# Altium schematic sheet LED.SchDoc(LED)
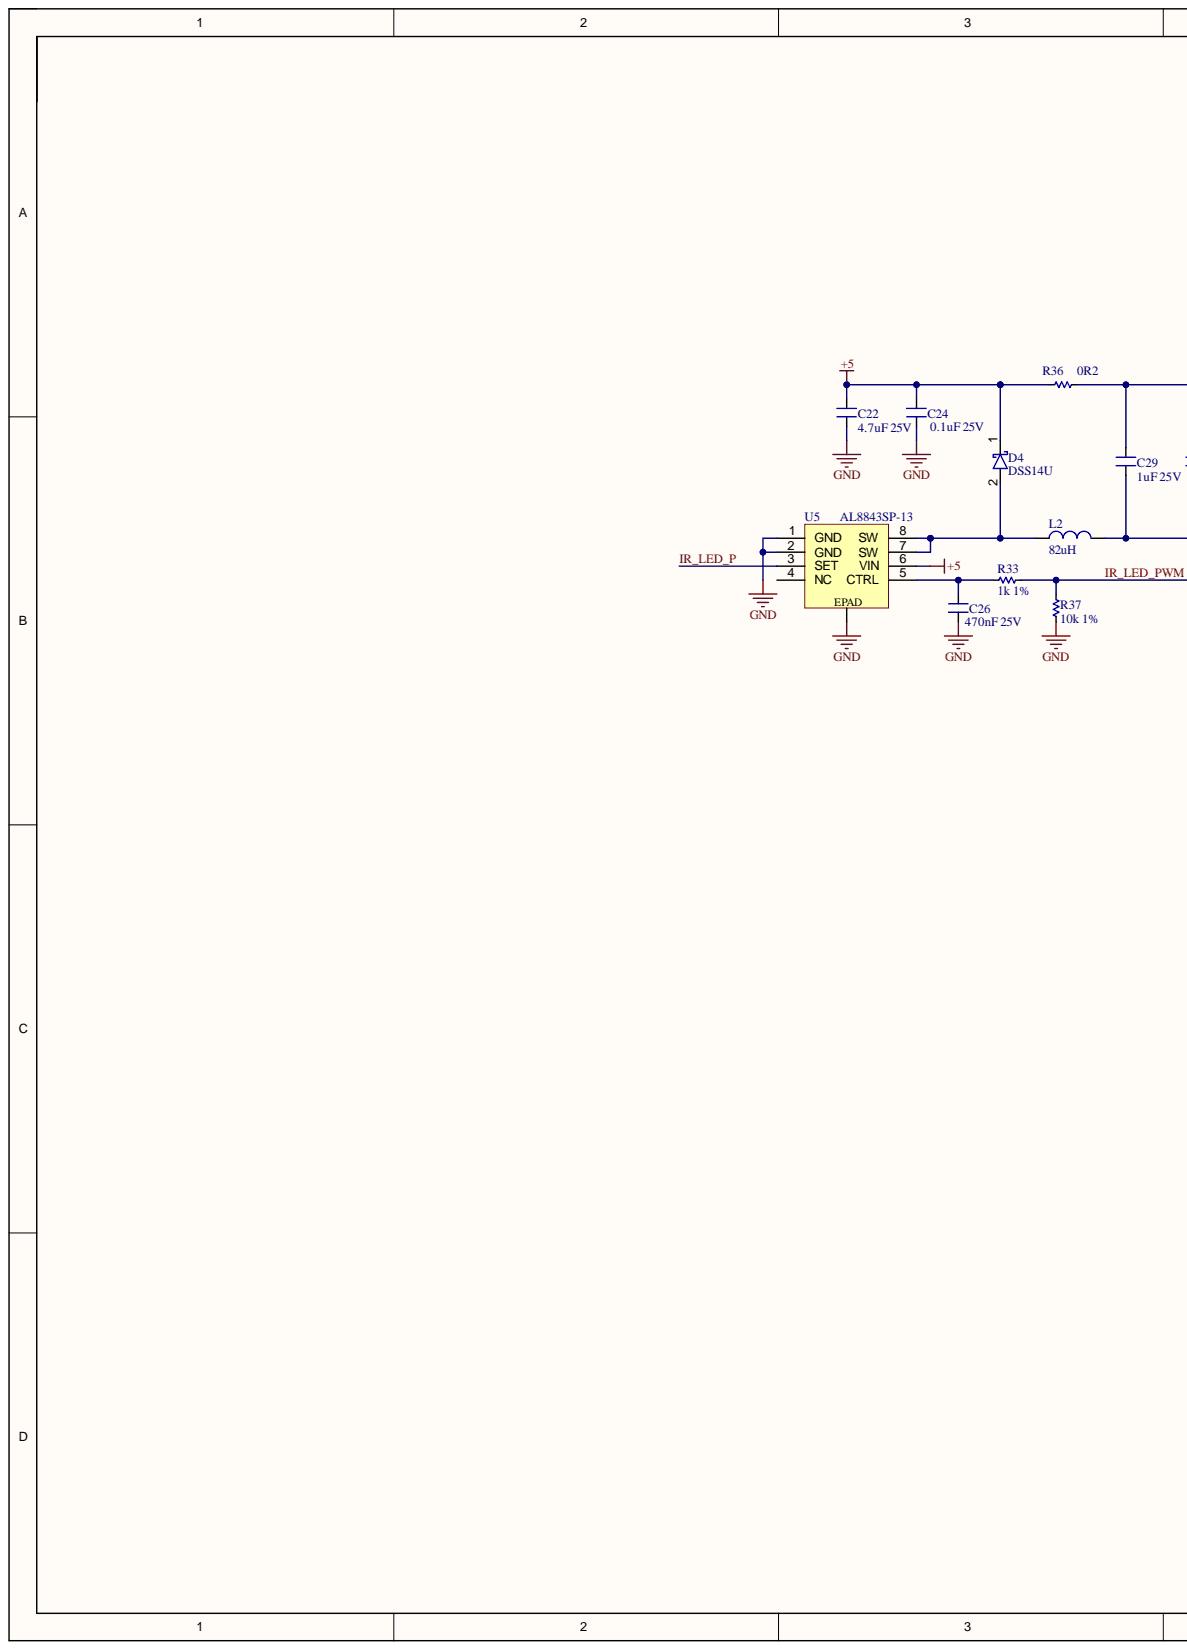
[diagram p0: LED.SchDoc, left]
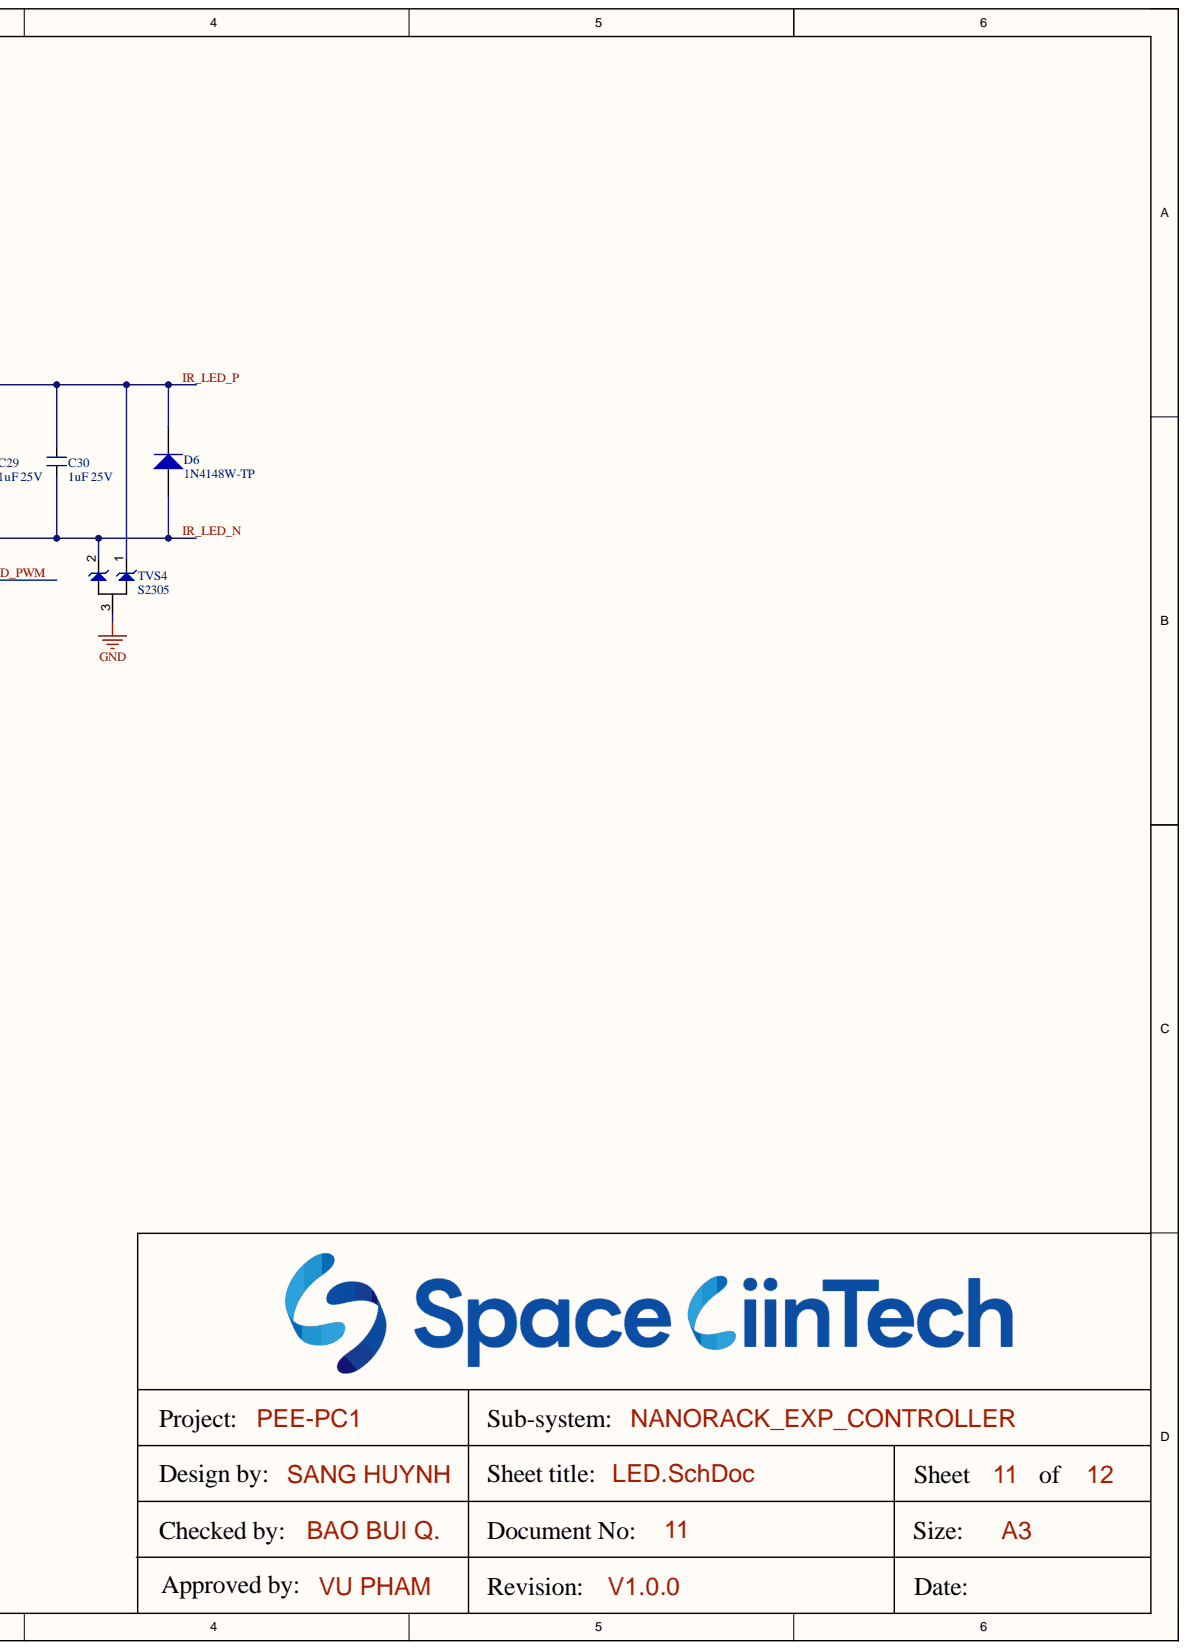
[diagram p1: LED.SchDoc, right]

## Parts
C22  4.7uF 25V  [CN-SMD-0603]
C24  0.1uF 25V  [CN-SMD-0603]
C26  470nF 25V  [CN-SMD-0603]
C29  1uF 25V  [CN-SMD-0603]
C30  1uF 25V  [CN-SMD-0603]
D4  DSS14U  [sod-123]
D6  1N4148W-TP  [SOD-123]
L2  82uH  [L-SMD-M-4.8x4.8x2.8mm]
R33  1k 1%  [RES-SMD-0603]
R36  0R2  [RES-SMD-1206]
R37  10k 1%  [RES-SMD-0603]
TVS4  S2305  [sot23-12_3]
U5  AL8843SP-13  [SO8_EPAD]

## Nets
+5: C22.2 C24.2 D4.1 R36.2 U5.6(VIN)
GND: C22.1 C24.1 C26.1 R37.1 TVS4.3 U5.1(GND) U5.2(GND) U5.EPAD
IR_LED_N: C29.1 C30.1 D6.1 L2.2 TVS4.2
IR_LED_P: C29.2 C30.2 D6.2 R36.1 TVS4.1 U5.3(SET)
IR_LED_PWM: R33.2 R37.2
NetC26_2: C26.2 R33.1 U5.5(CTRL)
NetD4_2: D4.2 L2.1 U5.7(SW) U5.8(SW)

Not connected: U5 4(NC)

## Library data of the parts
C22 C24 C26 C29 C30 = Capacitors: Value: 33pF; Component Kind: Standard; Library Name: Sang_library.SchLib
D6 = 1N4148-W-7: DIODE GEN PURP 100V 300MA SOD123; MFR: Diodes Incorporated; MPN: 1N4148W-7-F; Solder: SMT; Value: 100V/300MA; Component Kind: Standard; Library Name: Sang_library.SchLib
L2 = L-SMD-1: SRR4828A-820M; Manufacturer: Wurth Elektronik; partNo: 78477010; Inductance: 1.0 μH; Component Kind: Standard; ComponentLink1Description: Manufacturer; ComponentLink1URL: http://www.we-online.de; ComponentLink2Description: Datasheet; ComponentLink2URL: http://katalog.we-online.de/pbs/datasheet/78477010.pdf; Created Date: Nov.2015; Created by: Baojun Chen; Library Name: Sang_library.SchLib
R33 R37 = Res SMD: SMD; Manufacturer: Generic Components; Class: Passive; Component Kind: Standard; Published: 08/06/2000; Library Name: Sang_library.SchLib; Publisher: Altium Hobart Technology Centre
R36 = Res-SMD: RES 0.1 OHM 1% 1W 1206  PN: PE1206FRF470R1L; Manufacturer: Generic Components; Class: Passive; Component Kind: Standard; Library Name: Library.SchLib; Published: 08/06/2000; Publisher: Altium Hobart Technology Centre
TVS4 = S2305: TVS DIODE 5VWM 10.8VC SOT23; Component Kind: Standard; Library Name: Sang_library.SchLib
U5 = AL8843SP-13: IC LED DRIVER RGLTR PWM 3A 8SO; Component Kind: Standard; Library Name: Sang_library.SchLib
D4 = DSS14U: Component Kind: Standard; Library Name: Sang_library.SchLib
